# Altium schematic sheet MEDIABOARD-1A.SchDoc
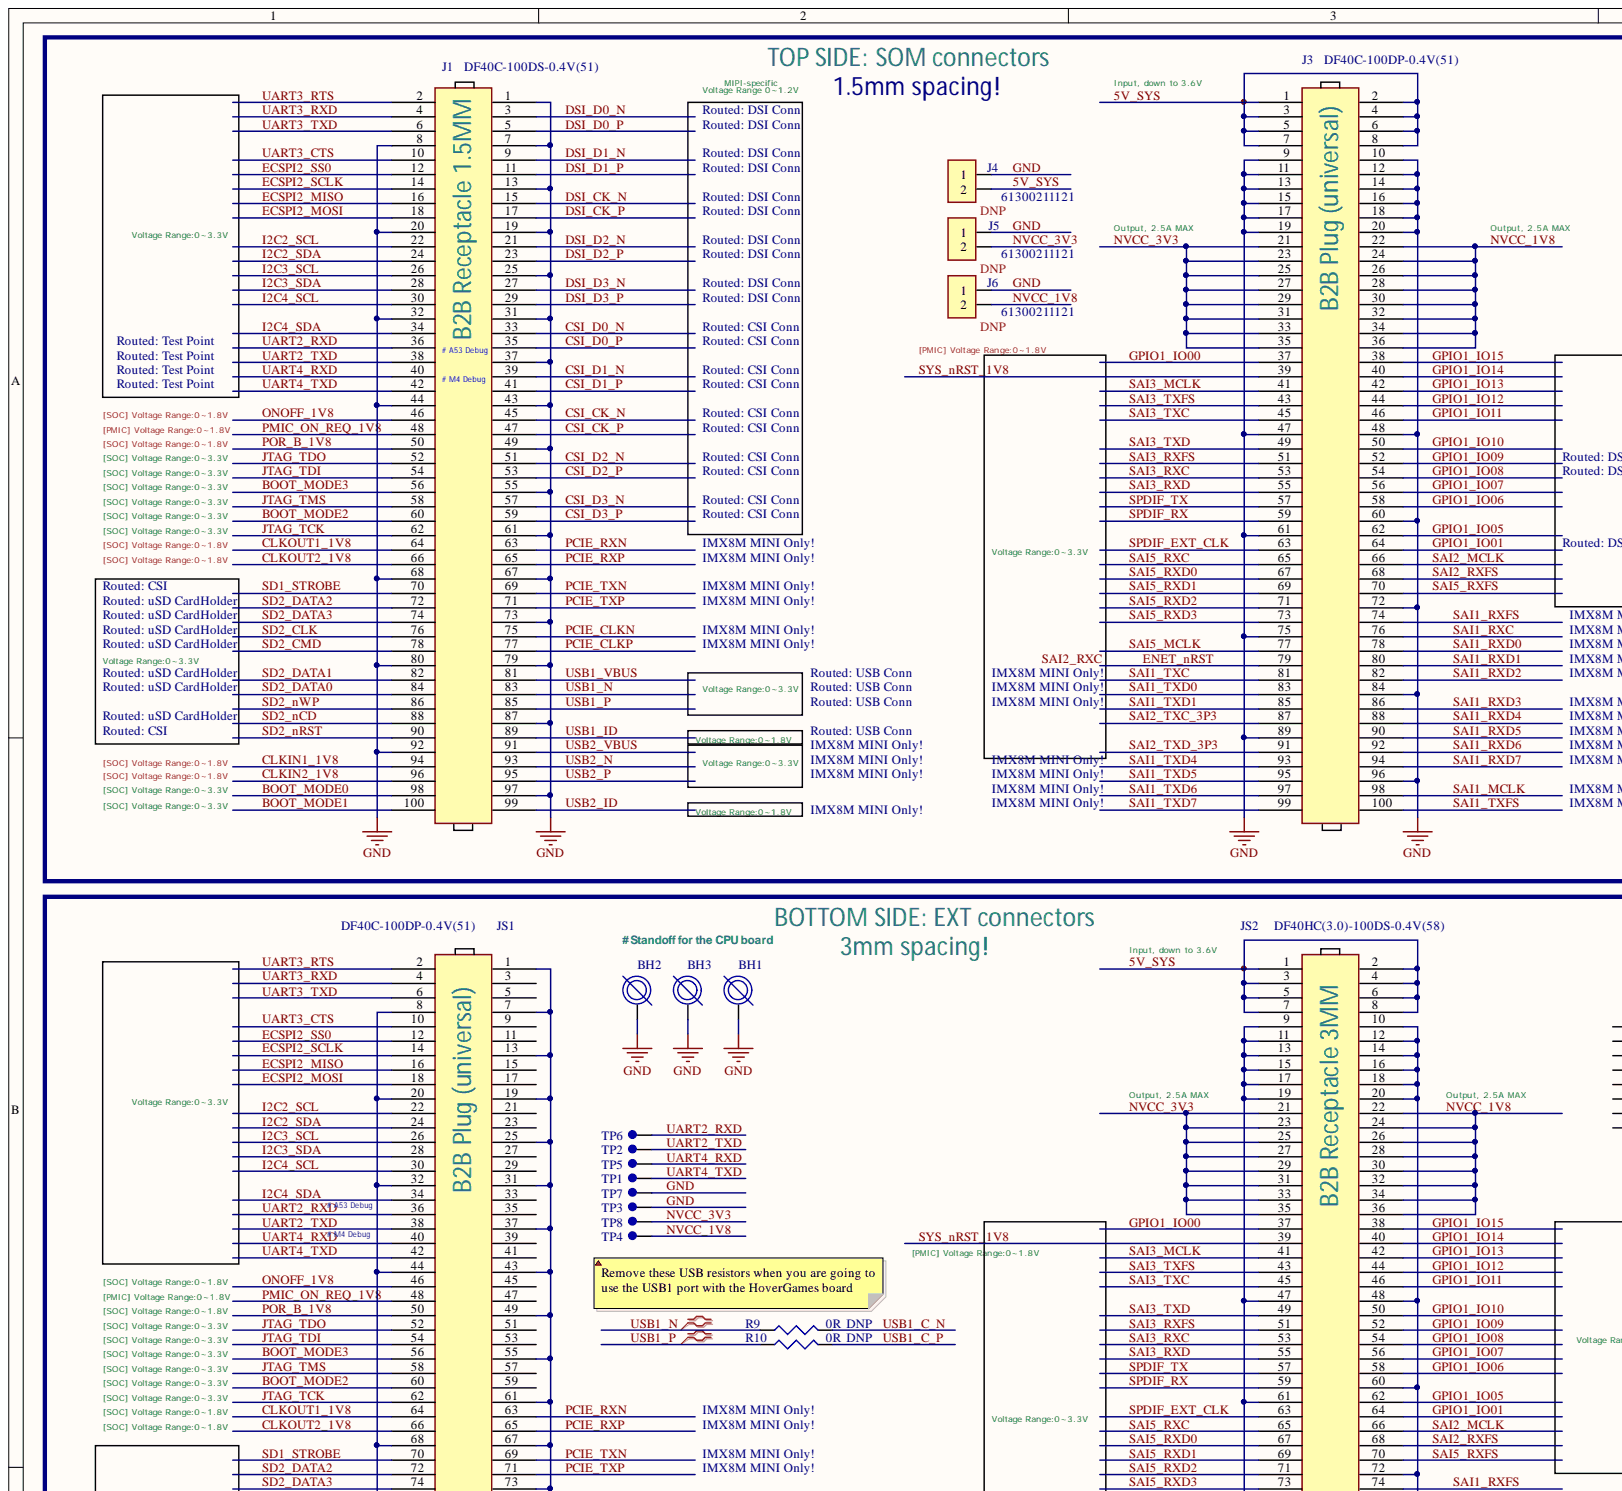
[diagram p0: MEDIABOARD-1A.SchDoc, top left]
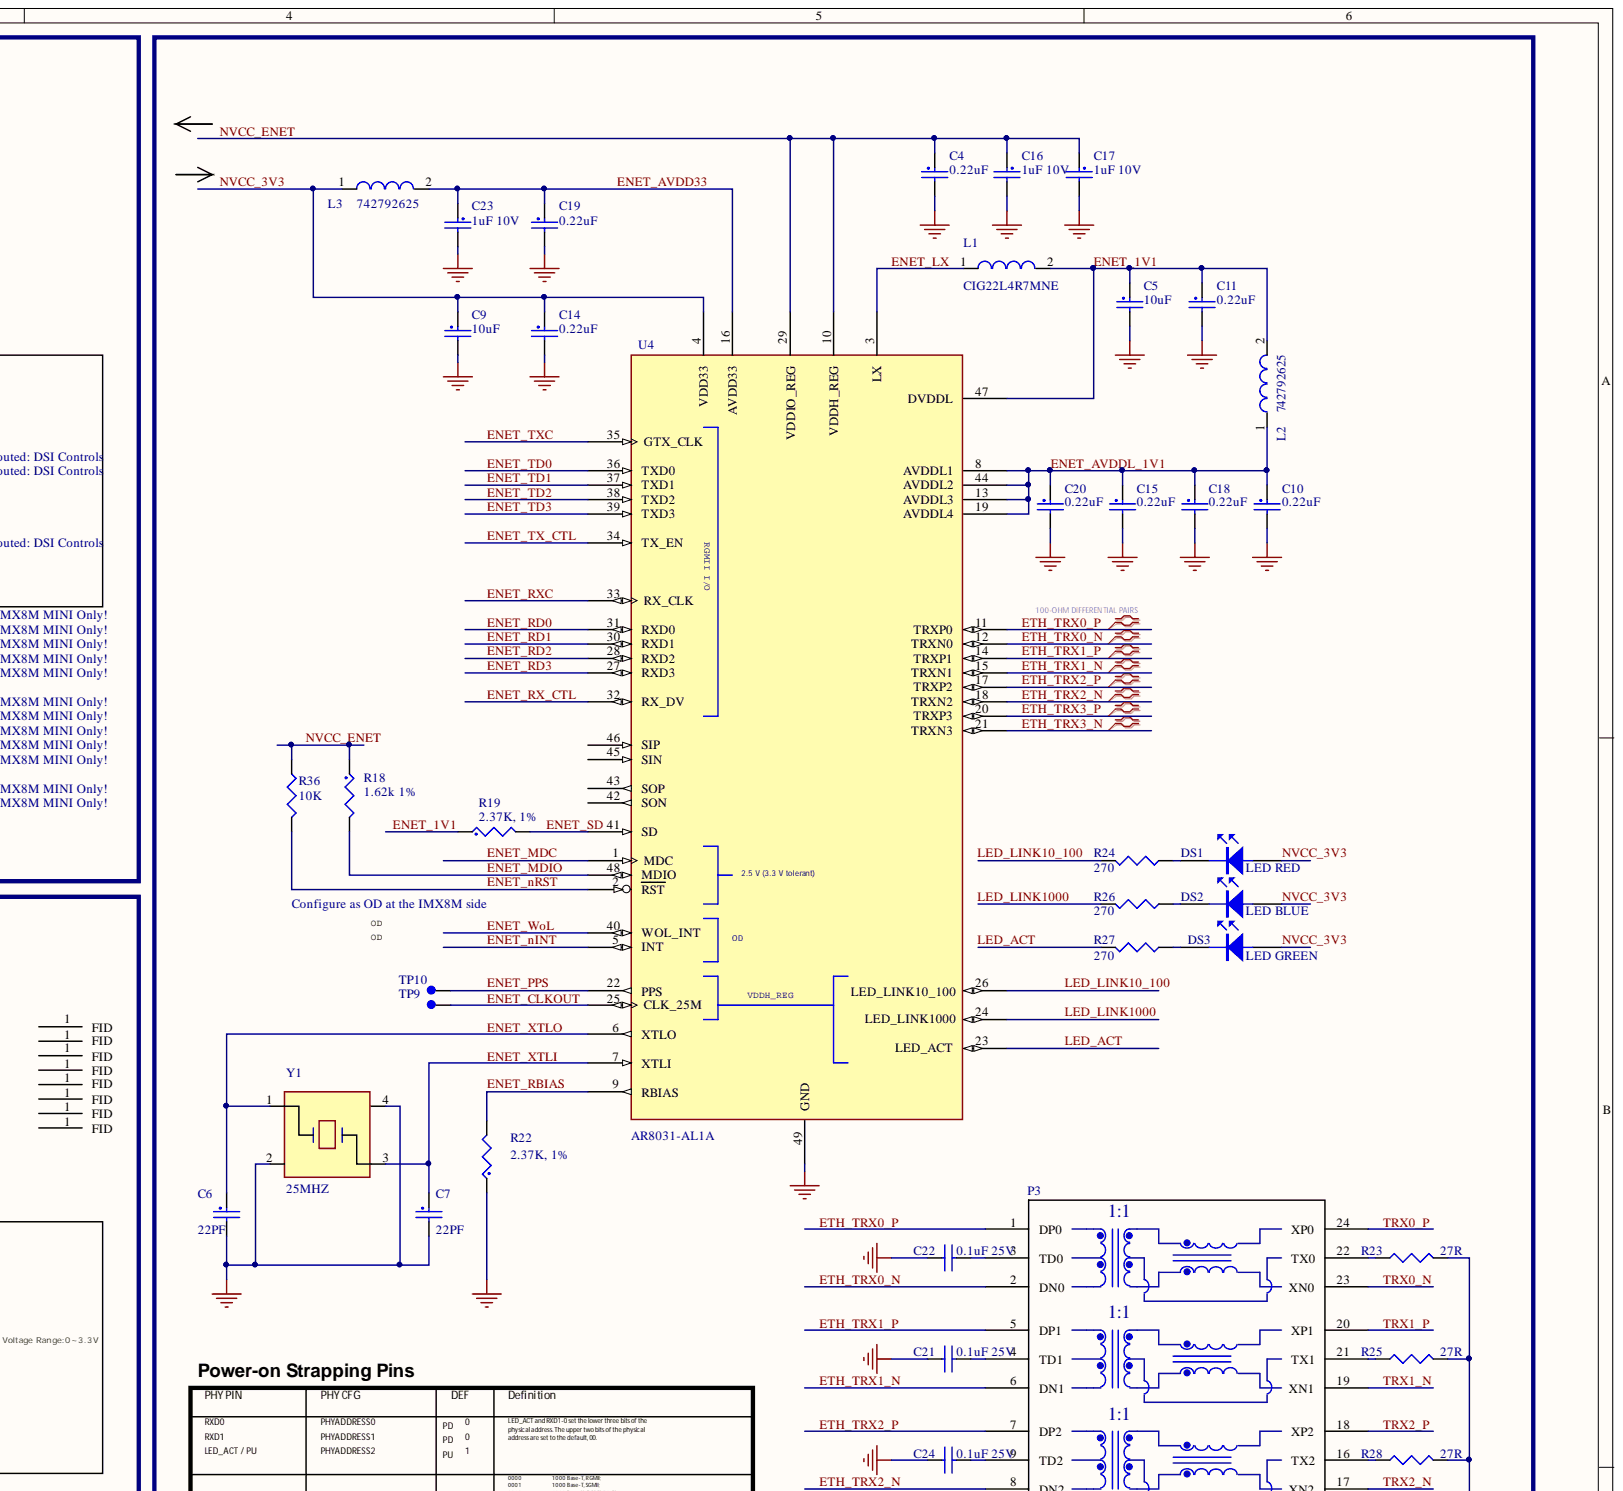
[diagram p1: MEDIABOARD-1A.SchDoc, top right]
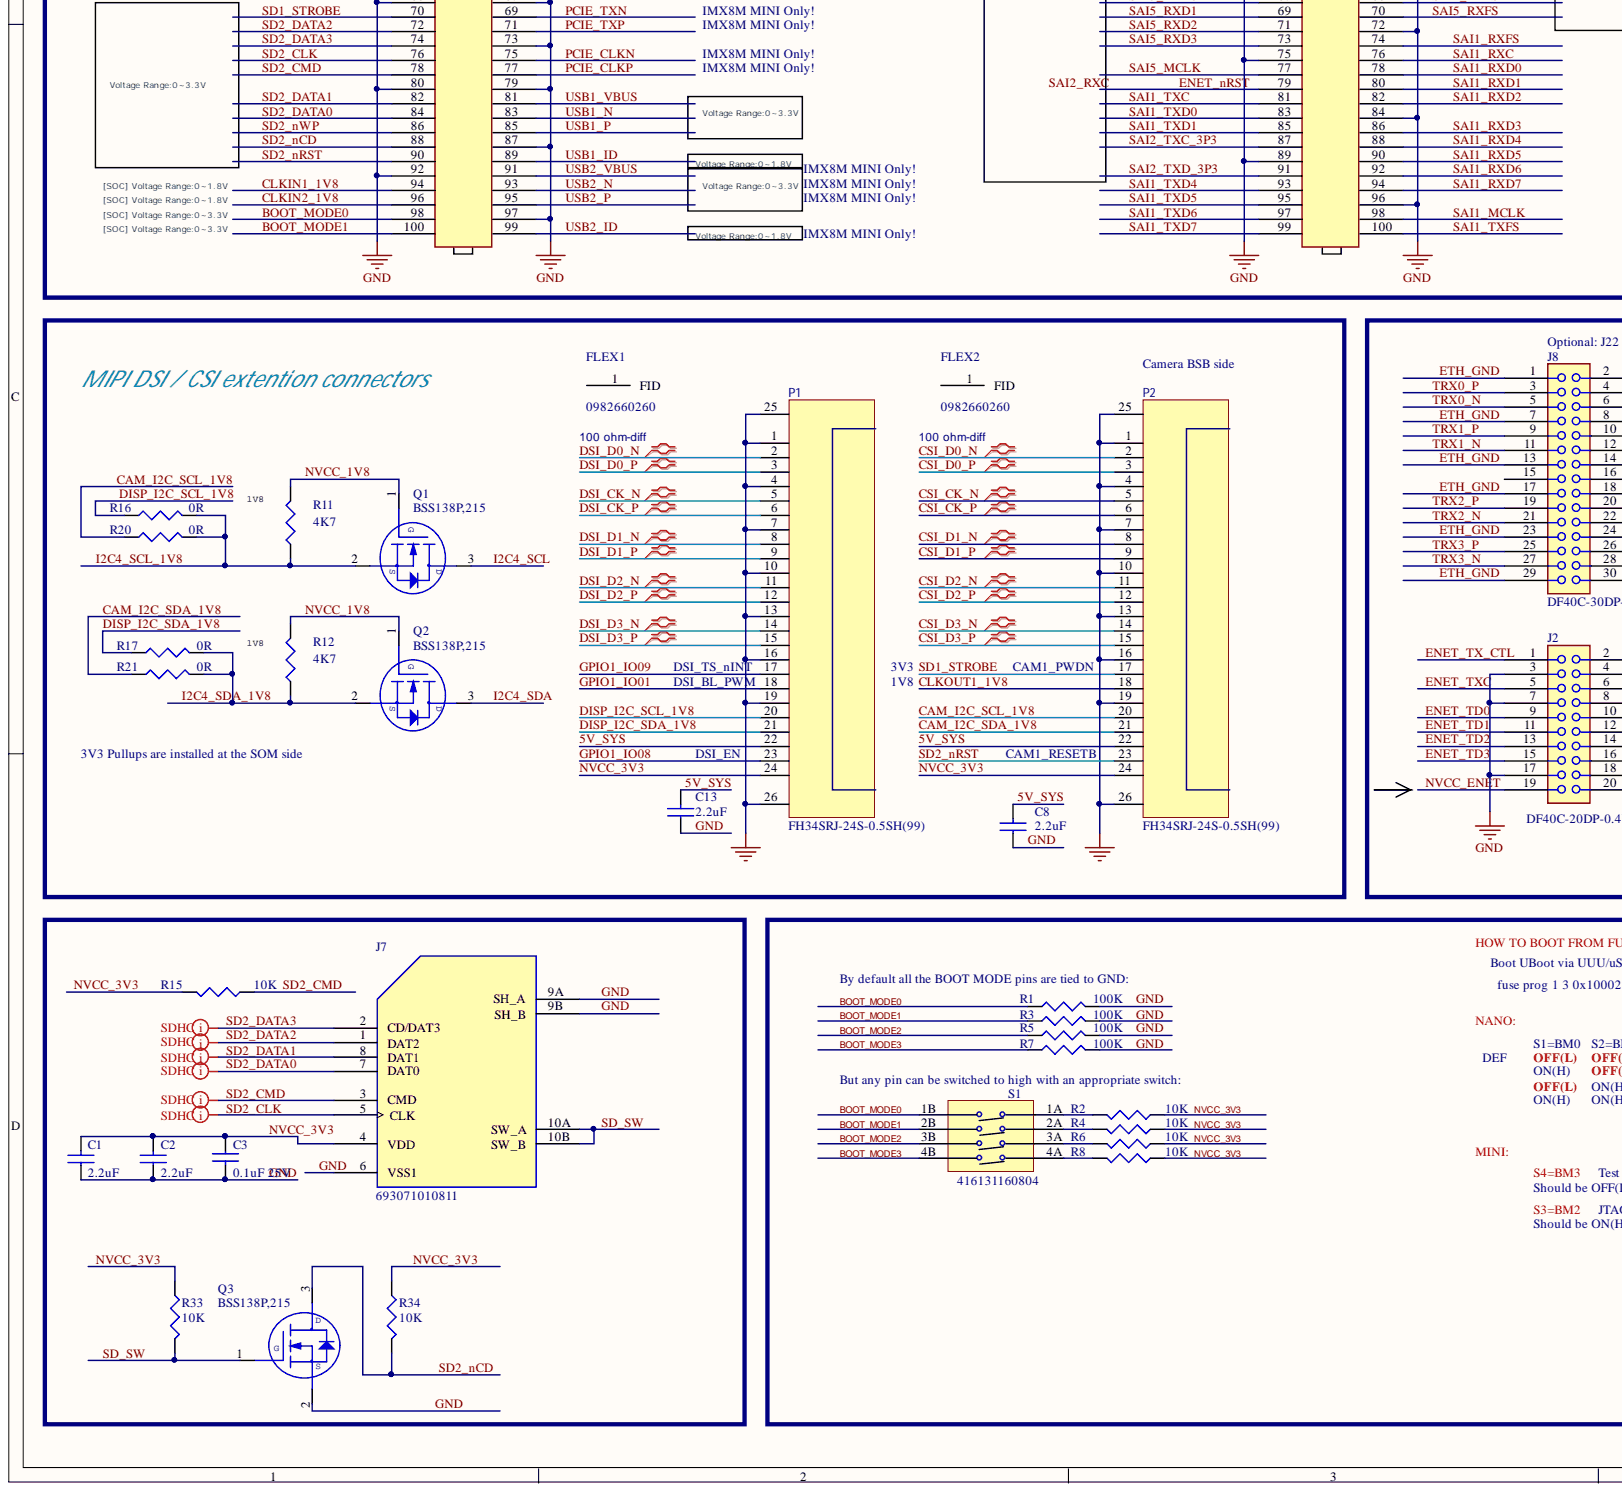
[diagram p2: MEDIABOARD-1A.SchDoc, bottom left]
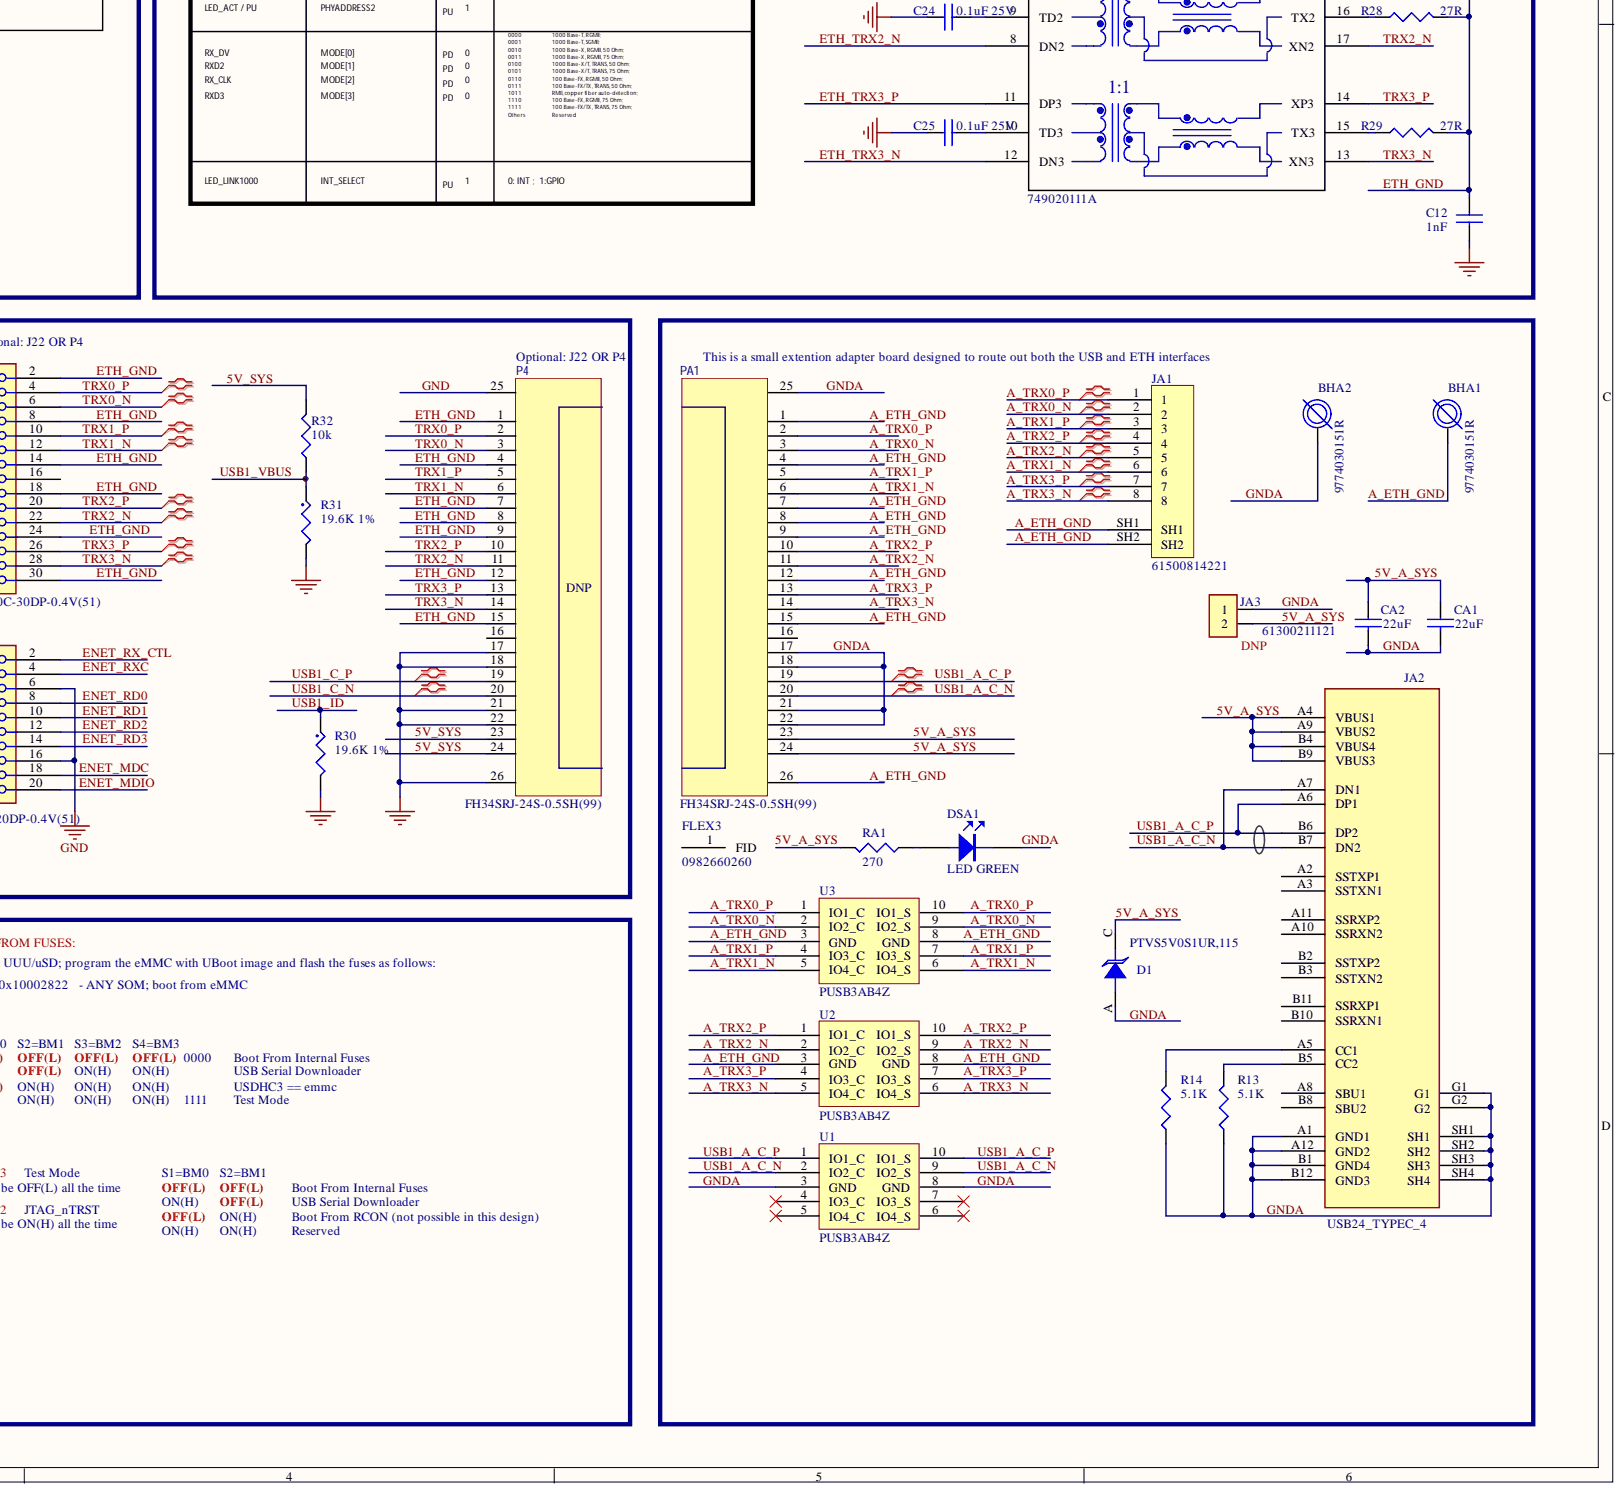
[diagram p3: MEDIABOARD-1A.SchDoc, bottom right]

## Parts
BH1  Spacer M2.5 1.5mm  [standoff_circle_5p1-2p5mm_nsp]  (value not drawn)
BH2  Spacer M2.5 1.5mm  [standoff_circle_5p1-2p5mm_nsp]  (value not drawn)
BH3  Spacer M2.5 1.5mm  [standoff_circle_5p1-2p5mm_nsp]  (value not drawn)
BHA1  9774030151R  [standoff_circle_5p1-2p5mm_nsp_3mm]
BHA2  9774030151R  [standoff_circle_5p1-2p5mm_nsp_3mm]
C1  2.2uF  [CAPC1608X95N]
C2  2.2uF  [CAPC1608X95N]
C3  0.1uF 25V  [CAPC1005X60N]
C4  0.22uF  [CAPC1005X60N]
C5  10uF  [CAPC1608X95N]
C6  22PF  [CAPC1005X60N]
C7  22PF  [CAPC1005X60N]
C8  2.2uF  [CAPC1608X95N]
C9  10uF  [CAPC1608X95N]
C10  0.22uF  [CAPC1005X60N]
C11  0.22uF  [CAPC1005X60N]
C12  1nF  [C1206]
C13  2.2uF  [CAPC1608X95N]
C14  0.22uF  [CAPC1005X60N]
C15  0.22uF  [CAPC1005X60N]
C16  1uF 10V  [CAPC1005X60N]
C17  1uF 10V  [CAPC1005X60N]
C18  0.22uF  [CAPC1005X60N]
C19  0.22uF  [CAPC1005X60N]
C20  0.22uF  [CAPC1005X60N]
C21  0.1uF 25V  [C0402]
C22  0.1uF 25V  [C0402]
C23  1uF 10V  [CAPC1005X60N]
C24  0.1uF 25V  [C0402]
C25  0.1uF 25V  [C0402]
CA1  22uF  [CAPC1608X95N]
CA2  22uF  [CAPC1608X95N]
D1  PTVS5V0S1UR,115  [SOD123W]
DS1  LED RED  [LED2012N]
DS2  LED BLUE  [LED2012N]
DS3  LED GREEN  [LED2012N]
DSA1  LED GREEN  [LED2012N]
FID1  FID  [FID_040]
FID2  FID  [FID_040]
FID3  FID  [FID_040]
FID4  FID  [FID_040]
FID5  FID  [FID_040]
FID6  FID  [FID_040]
FID7  FID  [FID_040]
FID8  FID  [FID_040]
FLEX1  0982660260
FLEX2  0982660260
FLEX3  0982660260
J1  DF40C-100DS-0.4V(51)  [con100_0p4_22p8x3p1_sm]
J2  DF40C-20DP-0.4V(51)  [con20_0p4_5p7x2p05_sm]
J3  DF40C-100DP-0.4V(51)  [con100_0p4_21p7x2p05_sm]
J4  61300211121  Notes: DNP  [CON-SAMTEC-TSW-102-07-T-S]
J5  61300211121  Notes: DNP  [CON-SAMTEC-TSW-102-07-T-S]
J6  61300211121  Notes: DNP  [CON-SAMTEC-TSW-102-07-T-S]
J7  693071010811  [CON_SDCARD_14X15P2_SM_RA]
J8  DF40C-30DP-0.4V(51)  [con30_0p4_5p7x2p05_sm]
JA1  61500814221  [MOLEX_0855055002]
JA2  USB24_TYPEC_4  [632723X00011]
JA3  61300211121  Notes: DNP  [CON-SAMTEC-TSW-102-07-T-S]
JS1  DF40C-100DP-0.4V(51)  [con100_0p4_21p7x2p05_sm]
JS2  DF40HC(3.0)-100DS-0.4V(58)  [con100_0p4_22p8x3p1_sm]
L1  CIG22L4R7MNE  [INDC2520X10N]
L2  742792625  [INDC1608X95N]
L3  742792625  [INDC1608X95N]
P1  FH34SRJ-24S-0.5SH(99)  [FPC24-0_5-14_18x4_71MM]
P2  FH34SRJ-24S-0.5SH(99)  [FPC24-0_5-14_18x4_71MM]
P3  749020111A  [749020111]
P4  FH34SRJ-24S-0.5SH(99)  Notes: DNP  [FPC24-0_5-14_18x4_71MM]
PA1  FH34SRJ-24S-0.5SH(99)  [FPC24-0_5-14_18x4_71MM]
Q1  BSS138P,215  [SOT23]
Q2  BSS138P,215  [SOT23]
Q3  BSS138P,215  [SOT23]
R1  100K  [R0402]
R2  10K  [R0402]
R3  100K  [R0402]
R4  10K  [R0402]
R5  100K  [R0402]
R6  10K  [R0402]
R7  100K  [R0402]
R8  10K  [R0402]
R9  0R  Notes: DNP  [R0402]
R10  0R  Notes: DNP  [R0402]
R11  4K7  [R0402]
R12  4K7  [R0402]
R13  5.1K  [R0402]
R14  5.1K  [R0402]
R15  10K  [R0402]
R16  0R  [R0402]
R17  0R  [R0402]
R18  1.62k 1%  [RESC1005N]
R19  2.37K, 1%  [RESC1005N]
R20  0R  [R0402]
R21  0R  [R0402]
R22  2.37K, 1%  [RESC1005N]
R23  27R  [R0402]
R24  270  [RESC1005N]
R25  27R  [R0402]
R26  270  [RESC1005N]
R27  270  [RESC1005N]
R28  27R  [R0402]
R29  27R  [R0402]
R30  19.6K 1%  [RESC1005N]
R31  19.6K 1%  [RESC1005N]
R32  10k  [RESC1005N]
R33  10K  [R0402]
R34  10K  [R0402]
R36  10K  [R0402]
RA1  270  [RESC1005N]
S1  416131160804  [416131160804]
TP1  Test pad  [TEST1MM]  (value not drawn)
TP2  Test pad  [TEST1MM]  (value not drawn)
TP3  Test pad  [TEST1MM]  (value not drawn)
TP4  Test pad  [TEST1MM]  (value not drawn)
TP5  Test pad  [TEST1MM]  (value not drawn)
TP6  Test pad  [TEST1MM]  (value not drawn)
TP7  Test pad  [TEST1MM]  (value not drawn)
TP8  Test pad  [TEST1MM]  (value not drawn)
TP9  Test pad  [TEST1MM]  (value not drawn)
TP10  Test pad  [TEST1MM]  (value not drawn)
U1  PUSB3AB4Z  [TI_TPD4E05U06DQAR]
U2  PUSB3AB4Z  [TI_TPD4E05U06DQAR]
U3  PUSB3AB4Z  [TI_TPD4E05U06DQAR]
U4  AR8031-AL1A  [QFN48_0P4_6X6MM_EPAD]
Y1  25MHZ  [CTS_403]

## Nets
5V_A_SYS: CA1.1 CA2.1 D1.C JA2.A4(VBUS1) JA2.A9(VBUS2) JA2.B4(VBUS4) JA2.B9(VBUS3) JA3.2 PA1.23 PA1.24 RA1.2
5V_SYS: C8.2 C13.2 J3.1 J3.2 J3.3 J3.4 J3.5 J3.6 J3.7 J3.8 J4.2 JS2.1 JS2.2 JS2.3 JS2.4 JS2.5 JS2.6 JS2.7 JS2.8 P1.22 P2.22 P4.23 P4.24 R32.1
A_ETH_GND: BHA1.1 JA1.SH1 JA1.SH2 PA1.1 PA1.4 PA1.7 PA1.8 PA1.9 PA1.12 PA1.15 PA1.26 U2.3(GND) U2.8(GND) U3.3(GND) U3.8(GND)
A_TRX0_N: JA1.2 PA1.3 U3.2(IO2_C) U3.9(IO2_S)
A_TRX0_P: JA1.1 PA1.2 U3.1(IO1_C) U3.10(IO1_S)
A_TRX1_N: JA1.6 PA1.6 U3.5(IO4_C) U3.6(IO4_S)
A_TRX1_P: JA1.3 PA1.5 U3.4(IO3_C) U3.7(IO3_S)
A_TRX2_N: JA1.5 PA1.11 U2.2(IO2_C) U2.9(IO2_S)
A_TRX2_P: JA1.4 PA1.10 U2.1(IO1_C) U2.10(IO1_S)
A_TRX3_N: JA1.8 PA1.14 U2.5(IO4_C) U2.6(IO4_S)
A_TRX3_P: JA1.7 PA1.13 U2.4(IO3_C) U2.7(IO3_S)
BOOT_MODE0: J1.98 JS1.98 R1.1 S1.1B
BOOT_MODE1: J1.100 JS1.100 R3.1 S1.2B
BOOT_MODE2: J1.60 JS1.60 R5.1 S1.3B
BOOT_MODE3: J1.56 JS1.56 R7.1 S1.4B
CAM_I2C_SCL_1V8: P2.20 R20.1
CAM_I2C_SDA_1V8: P2.21 R21.1
CLKIN1_1V8: J1.94 JS1.94
CLKIN2_1V8: J1.96 JS1.96
CLKOUT1_1V8: J1.64 JS1.64 P2.18
CLKOUT2_1V8: J1.66 JS1.66
CSI_CK_N: J1.45 P2.5
CSI_CK_P: J1.47 P2.6
CSI_D0_N: J1.33 P2.2
CSI_D0_P: J1.35 P2.3
CSI_D1_N: J1.39 P2.8
CSI_D1_P: J1.41 P2.9
CSI_D2_N: J1.51 P2.11
CSI_D2_P: J1.53 P2.12
CSI_D3_N: J1.57 P2.14
CSI_D3_P: J1.59 P2.15
DISP_I2C_SCL_1V8: P1.20 R16.1
DISP_I2C_SDA_1V8: P1.21 R17.1
DSI_CK_N: J1.15 P1.5
DSI_CK_P: J1.17 P1.6
DSI_D0_N: J1.3 P1.2
DSI_D0_P: J1.5 P1.3
DSI_D1_N: J1.9 P1.8
DSI_D1_P: J1.11 P1.9
DSI_D2_N: J1.21 P1.11
DSI_D2_P: J1.23 P1.12
DSI_D3_N: J1.27 P1.14
DSI_D3_P: J1.29 P1.15
ECSPI2_MISO: J1.16 JS1.16
ECSPI2_MOSI: J1.18 JS1.18
ECSPI2_SCLK: J1.14 JS1.14
ECSPI2_SS0: J1.12 JS1.12
ENET_1V1: C5.1 C11.1 L1.2 L2.2 R19.1 U4.47(DVDDL)
ENET_AVDD33: C19.1 C23.1 L3.2 U4.16(AVDD33)
ENET_AVDDL_1V1: C10.1 C15.1 C18.1 C20.1 L2.1 U4.8(AVDDL1) U4.13(AVDDL3) U4.19(AVDDL4) U4.44(AVDDL2)
ENET_CLKOUT: TP9.1 U4.25(CLK_25M)
ENET_LX: L1.1 U4.3(LX)
ENET_MDC: J2.18 U4.1(MDC)
ENET_MDIO: J2.20 R18.2 U4.48(MDIO)
ENET_nINT: U4.5(INT)
ENET_nRST: J3.79 JS2.79 R36.2 U4.2(RST)
ENET_PPS: TP10.1 U4.22(PPS)
ENET_RBIAS: R22.2 U4.9(RBIAS)
ENET_RD0: J2.8 U4.31(RXD0)
ENET_RD1: J2.10 U4.30(RXD1)
ENET_RD2: J2.12 U4.28(RXD2)
ENET_RD3: J2.14 U4.27(RXD3)
ENET_RX_CTL: J2.2 U4.32(RX_DV)
ENET_RXC: J2.4 U4.33(RX_CLK)
ENET_SD: R19.2 U4.41(SD)
ENET_TD0: J2.9 U4.36(TXD0)
ENET_TD1: J2.11 U4.37(TXD1)
ENET_TD2: J2.13 U4.38(TXD2)
ENET_TD3: J2.15 U4.39(TXD3)
ENET_TX_CTL: J2.1 U4.34(TX_EN)
ENET_TXC: J2.5 U4.35(GTX_CLK)
ENET_WoL: U4.40(WOL_INT)
ENET_XTLI: C7.1 U4.7(XTLI) Y1.3
ENET_XTLO: C6.1 U4.6(XTLO) Y1.1
ETH_GND: C12.1 J8.1 J8.2 J8.7 J8.8 J8.13 J8.14 J8.17 J8.18 J8.23 J8.24 J8.29 J8.30 P4.1 P4.4 P4.7 P4.8 P4.9 P4.12 P4.15 R23.1 R25.1 R28.1 R29.1
ETH_TRX0_N: P3.2(DN0) U4.12(TRXN0)
ETH_TRX0_P: P3.1(DP0) U4.11(TRXP0)
ETH_TRX1_N: P3.6(DN1) U4.15(TRXN1)
ETH_TRX1_P: P3.5(DP1) U4.14(TRXP1)
ETH_TRX2_N: P3.8(DN2) U4.18(TRXN2)
ETH_TRX2_P: P3.7(DP2) U4.17(TRXP2)
ETH_TRX3_N: P3.12(DN3) U4.21(TRXN3)
ETH_TRX3_P: P3.11(DP3) U4.20(TRXP3)
GND: BH1.1 BH2.1 BH3.1 C1.1 C2.1 C3.2 C4.2 C5.2 C6.2 C7.2 C8.1 C9.2 C10.2 C11.2 C12.2 C13.1 C14.2 C15.2 C16.2 C17.2 C18.2 C19.2 C20.2 C21.1 C22.1 C23.2 C24.1 C25.1 J1.1 J1.7 J1.8 J1.13 J1.19 J1.20 J1.25 J1.31 J1.32 J1.37 J1.43 J1.44 J1.49 J1.55 J1.61 J1.67 J1.68 J1.73 J1.79 J1.80 J1.87 J1.92 J1.97 J2.3 J2.6 J2.7 J2.16 J2.17 J3.9 J3.10 J3.11 J3.12 J3.13 J3.14 J3.15 J3.16 J3.17 J3.18 J3.19 J3.20 J3.47 J3.48 J3.60 J3.61 J3.72 J3.75 J3.84 J3.89 J3.96 J4.1 J5.1 J6.1 J7.6(VSS1) J7.9A(SH_A) J7.9B(SH_B) JS1.1 JS1.7 JS1.8 JS1.13 JS1.19 JS1.20 JS1.25 JS1.31 JS1.32 JS1.37 JS1.43 JS1.44 JS1.49 JS1.55 JS1.61 JS1.67 JS1.68 JS1.73 JS1.79 JS1.80 JS1.87 JS1.92 JS1.97 JS2.9 JS2.10 JS2.11 JS2.12 JS2.13 JS2.14 JS2.15 JS2.16 JS2.17 JS2.18 JS2.19 JS2.20 JS2.47 JS2.48 JS2.60 JS2.61 JS2.72 JS2.75 JS2.84 JS2.89 JS2.96 P1.1 P1.4 P1.7 P1.10 P1.13 P1.16 P1.19 P1.25 P1.26 P2.1 P2.4 P2.7 P2.10 P2.13 P2.16 P2.19 P2.25 P2.26 P4.17 P4.18 P4.21 P4.22 P4.25 P4.26 Q3.2 R1.2 R3.2 R5.2 R7.2 R22.1 R30.2 R31.2 TP3.1 TP7.1 U4.49(GND) Y1.2 Y1.4
GNDA: BHA2.1 CA1.2 CA2.2 D1.A DSA1.2 JA2.A1(GND1) JA2.A12(GND2) JA2.B1(GND4) JA2.B12(GND3) JA2.G1 JA2.G2 JA2.SH1 JA2.SH2 JA2.SH3 JA2.SH4 JA3.1 PA1.17 PA1.18 PA1.21 PA1.22 PA1.25 R13.1 R14.1 U1.3(GND) U1.8(GND)
GPIO1_IO00: J3.37 JS2.37
GPIO1_IO01: J3.64 JS2.64 P1.18
GPIO1_IO05: J3.62 JS2.62
GPIO1_IO06: J3.58 JS2.58
GPIO1_IO07: J3.56 JS2.56
GPIO1_IO08: J3.54 JS2.54 P1.23
GPIO1_IO09: J3.52 JS2.52 P1.17
GPIO1_IO10: J3.50 JS2.50
GPIO1_IO11: J3.46 JS2.46
GPIO1_IO12: J3.44 JS2.44
GPIO1_IO13: J3.42 JS2.42
GPIO1_IO14: J3.40 JS2.40
GPIO1_IO15: J3.38 JS2.38
I2C2_SCL: J1.22 JS1.22
I2C2_SDA: J1.24 JS1.24
I2C3_SCL: J1.26 JS1.26
I2C3_SDA: J1.28 JS1.28
I2C4_SCL: J1.30 JS1.30 Q1.3
I2C4_SCL_1V8: Q1.2 R11.1 R16.2 R20.2
I2C4_SDA: J1.34 JS1.34 Q2.3
I2C4_SDA_1V8: Q2.2 R12.1 R17.2 R21.2
JTAG_TCK: J1.62 JS1.62
JTAG_TDI: J1.54 JS1.54
JTAG_TDO: J1.52 JS1.52
JTAG_TMS: J1.58 JS1.58
LED_ACT: R27.2 U4.23(LED_ACT)
LED_LINK10_100: R24.2 U4.26(LED_LINK10_100)
LED_LINK1000: R26.2 U4.24(LED_LINK1000)
NVCC_1V8: J3.22 J3.24 J3.26 J3.28 J3.30 J3.32 J3.34 J3.36 J6.2 JS2.22 JS2.24 JS2.26 JS2.28 JS2.30 JS2.32 JS2.34 JS2.36 Q1.1 Q2.1 R11.2 R12.2 TP4.1
NVCC_3V3: C1.2 C2.2 C3.1 C9.1 C14.1 DS1.1 DS2.1 DS3.1 J3.21 J3.23 J3.25 J3.27 J3.29 J3.31 J3.33 J3.35 J5.2 J7.4(VDD) JS2.21 JS2.23 JS2.25 JS2.27 JS2.29 JS2.31 JS2.33 JS2.35 L3.1 P1.24 P2.24 R2.1 R4.1 R6.1 R8.1 R15.2 R33.1 R34.1 TP8.1 U4.4(VDD33)
NVCC_ENET: C4.1 C16.1 C17.1 J2.19 R18.1 R36.1 U4.10(VDDH_REG) U4.29(VDDIO_REG)
ONOFF_1V8: J1.46 JS1.46
PCIE_CLKN: J1.75 JS1.75
PCIE_CLKP: J1.77 JS1.77
PCIE_RXN: J1.63 JS1.63
PCIE_RXP: J1.65 JS1.65
PCIE_TXN: J1.69 JS1.69
PCIE_TXP: J1.71 JS1.71
PMIC_ON_REQ_1V8: J1.48 JS1.48
POR_B_1V8: J1.50 JS1.50
SAI1_MCLK: J3.98 JS2.98
SAI1_RXC: J3.76 JS2.76
SAI1_RXD0: J3.78 JS2.78
SAI1_RXD1: J3.80 JS2.80
SAI1_RXD2: J3.82 JS2.82
SAI1_RXD3: J3.86 JS2.86
SAI1_RXD4: J3.88 JS2.88
SAI1_RXD5: J3.90 JS2.90
SAI1_RXD6: J3.92 JS2.92
SAI1_RXD7: J3.94 JS2.94
SAI1_RXFS: J3.74 JS2.74
SAI1_TXC: J3.81 JS2.81
SAI1_TXD0: J3.83 JS2.83
SAI1_TXD1: J3.85 JS2.85
SAI1_TXD4: J3.93 JS2.93
SAI1_TXD5: J3.95 JS2.95
SAI1_TXD6: J3.97 JS2.97
SAI1_TXD7: J3.99 JS2.99
SAI1_TXFS: J3.100 JS2.100
SAI2_MCLK: J3.66 JS2.66
SAI2_RXFS: J3.68 JS2.68
SAI2_TXC_3P3: J3.87 JS2.87
SAI2_TXD_3P3: J3.91 JS2.91
SAI3_MCLK: J3.41 JS2.41
SAI3_RXC: J3.53 JS2.53
SAI3_RXD: J3.55 JS2.55
SAI3_RXFS: J3.51 JS2.51
SAI3_TXC: J3.45 JS2.45
SAI3_TXD: J3.49 JS2.49
SAI3_TXFS: J3.43 JS2.43
SAI5_MCLK: J3.77 JS2.77
SAI5_RXC: J3.65 JS2.65
SAI5_RXD0: J3.67 JS2.67
SAI5_RXD1: J3.69 JS2.69
SAI5_RXD2: J3.71 JS2.71
SAI5_RXD3: J3.73 JS2.73
SAI5_RXFS: J3.70 JS2.70
SD1_STROBE: J1.70 JS1.70 P2.17
SD2_CLK: J1.76 J7.5(CLK) JS1.76
SD2_CMD: J1.78 J7.3(CMD) JS1.78 R15.1
SD2_DATA0: J1.84 J7.7(DAT0) JS1.84
SD2_DATA1: J1.82 J7.8(DAT1) JS1.82
SD2_DATA2: J1.72 J7.1(DAT2) JS1.72
SD2_DATA3: J1.74 J7.2(CD/DAT3) JS1.74
SD2_nCD: J1.88 JS1.88 Q3.3 R34.2
SD2_nRST: J1.90 JS1.90 P2.23
SD2_nWP: J1.86 JS1.86
SD_SW: J7.10A(SW_A) J7.10B(SW_B) Q3.1 R33.2
SPDIF_EXT_CLK: J3.63 JS2.63
SPDIF_RX: J3.59 JS2.59
SPDIF_TX: J3.57 JS2.57
SYS_nRST_1V8: J3.39 JS2.39
TRX0_N: J8.5 J8.6 P3.23(XN0) P4.3
TRX0_P: J8.3 J8.4 P3.24(XP0) P4.2
TRX1_N: J8.11 J8.12 P3.19(XN1) P4.6
TRX1_P: J8.9 J8.10 P3.20(XP1) P4.5
TRX2_N: J8.21 J8.22 P3.17(XN2) P4.11
TRX2_P: J8.19 J8.20 P3.18(XP2) P4.10
TRX3_N: J8.27 J8.28 P3.13(XN3) P4.14
TRX3_P: J8.25 J8.26 P3.14(XP3) P4.13
UART2_RXD: J1.36 JS1.36 TP6.1
UART2_TXD: J1.38 JS1.38 TP2.1
UART3_CTS: J1.10 JS1.10
UART3_RTS: J1.2 JS1.2
UART3_RXD: J1.4 JS1.4
UART3_TXD: J1.6 JS1.6
UART4_RXD: J1.40 JS1.40 TP5.1
UART4_TXD: J1.42 JS1.42 TP1.1
USB1_A_C_N: JA2.A7(DN1) JA2.B7(DN2) PA1.20 U1.2(IO2_C) U1.9(IO2_S)
USB1_A_C_P: JA2.A6(DP1) JA2.B6(DP2) PA1.19 U1.1(IO1_C) U1.10(IO1_S)
USB1_C_N: P4.20 R9.2
USB1_C_P: P4.19 R10.2
USB1_ID: J1.89 JS1.89 R30.1
USB1_N: J1.83 JS1.83 R9.1
USB1_P: J1.85 JS1.85 R10.1
USB1_VBUS: J1.81 JS1.81 R31.1 R32.2
USB2_ID: J1.99 JS1.99
USB2_N: J1.93 JS1.93
USB2_P: J1.95 JS1.95
USB2_VBUS: J1.91 JS1.91
NetC21_2: C21.2 P3.4(TD1)
NetC22_2: C22.2 P3.3(TD0)
NetC24_2: C24.2 P3.9(TD2)
NetC25_2: C25.2 P3.10(TD3)
NetDS1_2: DS1.2 R24.1
NetDS2_2: DS2.2 R26.1
NetDS3_2: DS3.2 R27.1
NetDSA1_1: DSA1.1 RA1.1
NetJA2_A5: JA2.A5(CC1) R14.2
NetJA2_B5: JA2.B5(CC2) R13.2
NetP3_15: P3.15(TX3) R29.2
NetP3_16: P3.16(TX2) R28.2
NetP3_21: P3.21(TX1) R25.2
NetP3_22: P3.22(TX0) R23.2
NetR2_2: R2.2 S1.1A
NetR4_2: R4.2 S1.2A
NetR6_2: R6.2 S1.3A
NetR8_2: R8.2 S1.4A
SAI2_RXC:

Not connected: FID1 1; FID2 1; FID3 1; FID4 1; FID5 1; FID6 1; FID7 1; FID8 1; FLEX1 1; FLEX2 1; FLEX3 1; J8 15 16; JA2 A2(SSTXP1) A3(SSTXN1) A8(SBU1) A10(SSRXN2) A11(SSRXP2) B2(SSTXP2) B3(SSTXN2) B8(SBU2) B10(SSRXN1) B11(SSRXP1); JS1 3 5 9 11 15 17 21 23 27 29 33 35 39 41 45 47 51 53 57 59; P4 16; PA1 16; U1 4(IO3_C) 5(IO4_C) 6(IO4_S) 7(IO3_S); U4 42(SON) 43(SOP) 45(SIN) 46(SIP)

## Library data of the parts
BH1-BH3 = MOUNT_HOLE: ROUND SPACER STEEL 1.5MM; Manufacturer: Würth Elektronik; ManType: 9774015951R; Component Kind: Standard; DigiKey: 732-7070-1-ND
BHA1 BHA2 = MOUNT_HOLE: RND STANDOFF M2.5X0.45 STEEL 3MM; Manufacturer: Würth Elektronik; Component Kind: Standard; DigiKey: 732-7083-6-ND; ManType: 9774030151R
C1 C2 C8 C13 = CAP NP: CAP CER 2.2UF 10V X5R 0402; Manufacturer: Würth Elektronik; ManType: 885012105013; Component Kind: Standard; DigiKey: 732-7490-6-ND
C3 = CAP NP: CAP CER 0.1UF 25V X5R 0402; Manufacturer: Würth Elektronik; ManType: 885012105018; Notes: Option: C1005X5R0J104K; Component Kind: Standard; DigiKey: 732-7495-1-ND
C4 C10 C11 C14 C15 C18-C20 = CAP_NP_Dup1: CAP CER 0.22UF 16V X5R 0402; Manufacturer: Würth Elektronik; ManType: 885012105017; Component Kind: Standard; DigiKey: 732-7494-2-ND
C5 C9 = CAP_NP_Dup1: CAP CER 10UF 6.3V X5R 0603; Manufacturer: Würth Elektronik; ManType: 885012106006; Component Kind: Standard; DigiKey: 732-7903-1-ND
C6 C7 = CAP_NP_Dup1: CAP CER 22PF 50V 5% NPO 0402; Manufacturer: YAGEO; Part #: E-CAP-012; ManType: CC0402JRNPO9BN220; Component Kind: Standard; Digikey: 311-1018-2-ND; Package: 0402
C12 = CAP_CERAMIC: 1000pF 1kV 10%  X7R; Manufacturer: Murata Electronics; ManType: GRM31BR73A102KW01L; Component Kind: Standard; DigiKey: 490-3494-1-ND
C16 C17 C23 = CAP_NP_Dup1: CAP CER 1UF 10V X5R 0402; Manufacturer: Würth Elektronik; ManType: 885012105012; Component Kind: Standard; DigiKey: 732-7489-6-ND
C21 C22 C24 C25 = CAP NP: CAP CER 0.1UF 25V X5R 0402; Manufacturer: Würth Elektronik; ManType: 885012105018; Component Kind: Standard; DigiKey: 732-7495-1-ND
CA1 CA2 = CAP NP: 22uF +-20% 6.3V Ceramic Capacitor X5R 0603; Manufacturer: Samsung; Emcraft #: E-CAP-049; ManType: CL10A226MQ8NRNC; Component Kind: Standard; Digikey: 1276-1193-1-ND; Package: 0603; Part #: E-CAP-049; Price 100: 0.0850; Price 1000: 0.05250
D1 = DIODE_TVS: TVS DIODE 5V 9.2V CFP3; Manufacturer: Nexperia USA Inc.; Component Kind: Standard; Digikey: 1727-5229-1-ND; ManType: PTVS5V0S1UR,115
DS1 = LED_1: LED RED CLEAR 0805 SMD; Manufacturer: Würth Elektronik; ManType: 150080RS75000; Component Kind: Standard; DigiKey: 732-4984-6-ND
DS2 = LED_1: LED BLUE CLEAR 0805 SMD; Manufacturer: Würth Elektronik; ManType: 150080BS75000; Component Kind: Standard; DigiKey: 732-4982-1-ND
DS3 DSA1 = LED_1: LED GREEN CLEAR 0805 SMD; Manufacturer: Würth Elektronik; ManType: 150080GS75000; Component Kind: Standard; DigiKey: 732-4983-1-ND
FID1-FID8 = FID: Notes: DNP; Component Kind: Standard
FLEX1-FLEX3 = FID: CABLE FFC 24POS 0.50MM 6"; Manufacturer: Molex; Component Kind: Standard; DigiKey: WM14113-ND; ManType: 0982660260
J1 JS2 = HDR_2X50_M: CONN RCPT 100POS SMD GOLD; Manufacturer: Hirose Electric Co Ltd; Component Kind: Standard
  J1: DigiKey: H11615CT-ND; ManType: DF40C-100DS-0.4V(51)
  JS2: DigiKey: H124602CT-ND; ManType: DF40HC(3.0)-100DS-0.4V(58)
J2 = CONN_HDR_10X2: CONN PLUG 20POS SMD GOLD; Manufacturer: Hirose Electric Co Ltd; Component Kind: Standard; DigiKey: H11618CT-ND; ManType: DF40C-20DP-0.4V(51)
J3 JS1 = HDR_2X50_M: CONN PLUG 100POS SMD GOLD; Manufacturer: Hirose Electric Co Ltd; Component Kind: Standard; DigiKey: H11614CT-ND; ManType: DF40C-100DP-0.4V(51)
J4-J6 JA3 = JUMPER_1x2: CONN HEADER VERT 2POS 2.54MM; Manufacturer: Würth Elektronik; Component Kind: Standard; DigiKey: 732-5315-ND; ManType: 61300211121
J7 = MicroSD_WE: CONN MICRO SD CARD PUSH-PUSH R/A; Manufacturer: Würth Elektronik; Component Kind: Standard; Digikey: 732-3819-1-ND; Library Name: main.SchLib; ManType: 693071010811
J8 = CONN_HDR_15X2: CONN PLUG 30POS SMD GOLD; Manufacturer: Hirose Electric Co Ltd; Component Kind: Standard; DigiKey: H11622CT-ND; Library Name: main.SchLib; ManType: DF40C-30DP-0.4V(51)
JA1 = CONN8_PADS: CONN MOD JACK 8P8C R/A SHIELDED; Manufacturer: Würth Elektronik; Component Kind: Standard; DigiKey: 732-2111-ND; Library Name: main.SchLib; ManType: 61500814221
JA2 = USB24_TYPEC_4: CONN RCP USB3.1 TYPEC 24P SMD RA; Manufacturer: Würth Elektronik; ManType: 632723300011; Component Kind: Standard; Digikey: 732-9618-6-ND; Library Name: main.SchLib
L1 = INDUCTOR_Dup1: 4.7uH Shielded Multilayer Inductor 1.1A 110 mOhm 1008; Manufacturer: SAMSUNG; Component Kind: Standard; Digikey: 1276-6213-1-ND; ManType: CIG22L4R7MNE
L2 L3 = INDUCTOR_Dup1: FERRITE BEAD 120 OHM 0603 1LN; Manufacturer: Würth Elektronik; Component Kind: Standard; Digikey: 732-4665-1-ND; ManType: 742792625
P1 P2 P4 PA1 = CONN_ACES_50696-0240MV02: CONN FPC/FFC 24POS .5MM; Manufacturer: Hirose Electric Co Ltd; Component Kind: Standard; DigiKey: H124725CT-ND; ManType: FH34SRJ-24S-0.5SH(99); Library Name: SpacePark.SchLib
P3 = 749020111: WE-LAN 10/100/1000 BASE-T SMT TR; Manufacturer: Würth Elektronik; Component Kind: Standard; Digikey: 732-14052-1-ND; Library Name: main.SchLib; ManType: 749020111A
Q1-Q3 = MOSFET-N-GSD: MOSFET N-CH 60V 360MA TO-236AB; Manufacturer: Nexperia USA Inc.; Component Kind: Standard; DigiKey: 1727-1142-1-ND; ManType: BSS138P,215
R1 R3 R5 R7 = R_SM: RES, 100K, 1%, 1/10W, 0402; Manufacturer: Panasonic Electronic Components; ManType: ERJ-2RKF1003X; Component Kind: Standard; DigiKey: P100KLCT-ND
R2 R4 R6 R8 R15 R33 R34 R36 = R_SM: RES 10K OHM 1/16W 5% 0402; Manufacturer: Stackpole Electronics Inc; ManType: RMCF0402JT10K0; Component Kind: Standard; DigiKey: RMCF0402JT10K0CT-ND
R9 R10 R16 R17 R20 R21 = R_0201_0: RES 0.0 OHM 1/16W JUMP 0402; Manufacturer: STACKPOLE; Part #: E-RES-009; ManType: RMCF0402ZT0R00; Component Kind: Standard; Signal Integrity: RES; Simulation: RES; Library Name: links.SchLib
R11 R12 = R_SM: RES, 4K7, 5%, 1/10W, 0402; Manufacturer: Panasonic; Part #: E-RES-005; ManType: ERJ-2GEJ472X; Component Kind: Standard; PartNumber: ERJ-2GEJ472X
R13 R14 = R_SM: RES 5.1K OHM 1% 1/16W 0402; Manufacturer: Stackpole Electronics Inc; ManType: RMCF0402FT5K10; Component Kind: Standard; DigiKey: RMCF0402FT5K10CT-ND
R18 = RES_Dup1: RES SMD 1.62K OHM 1% 1/16W 0402; Manufacturer: Yageo; Part #: LF-RES-418; ManType: RC0402FR-071K62L; Component Kind: Standard; Digikey: YAG3042TR-ND; Package: 0402
R19 R22 = RES_Dup1: Res, 2.37K ohm, 1%, 0402; Manufacturer: Panasonic; Part #: I-RES-004; ManType: ERJ-2RKF2371X; Component Kind: Standard; Digikey: P2.37KLCT-ND; Package: 0402
R23 R25 R28 R29 = R_SM: RES, 27R, 1%, 1/10W, 0402; Manufacturer: Panasonic; Part #: E-RES-082; Part_Type: Resistor; PartNumber: ERJ-2RKF27R0X-1; Arena PN: 220-0328; Cat_Code: 220_RESISTOR; Circuit: LDS; Height_mm: 0.35; Library Rev: 1; ManType: ERJ-2RKF27R0X; REUSE_ANNOTATE: TRUE; Component Kind: Standard; Manufacturer's Part Number: ERJ-2RKF27R0X; Power: 1/10W
R24 R26 R27 RA1 = R_SH: RESISTOR TF 270 OHM 1% 0.0625W 0402; Manufacturer: Stackpole Electronics Inc; Part #: E-RES-008; ManType: RMCF0402FT270R; Component Kind: Standard; Digikey: RMCF0402FT270RCT-ND; Package: 0402; Price 100: 0.01050
R30 R31 = RES_Dup1: RES SMD 19.6K OHM 1% 1/16W 0402; Manufacturer: Yageo; Part #: E-RES-078; ARENA PN: 220-0478; ManType: RC0402FR-0719K6L; Component Kind: Standard; Digikey: YAG3026CT-ND; Package: 0402; Part#: E-RES-078; Price 100: 0.0055
R32 = R_SM: RES 10K OHM 1/16W 5% 0402; Manufacturer: Stackpole Electronics Inc; Part #: E-RES-003; ManType: RMCF0402JT10K0; Component Kind: Standard; Digikey: RMCF0402JT10K0CT-ND; Package: 0402; Price 100: 0.00700
S1 = 416131160804: SWITCH SLIDE DIP SPST 25MA 24V; Manufacturer: Wurth Electronics; Component Kind: Standard; DigiKey: 732-3854-1-ND; Library Name: main.SchLib; ManType: 416131160804
TP1-TP10 = Testpad: Round Test Point 1mm; CLASS: IC; Notes: DNP; Component Kind: Standard
U1-U3 = ESD224DQAR: TVS DIODE 3.3V 5V DFN2510A-10; Manufacturer: Nexperia USA Inc.; Component Kind: Standard; DigiKey: 1727-2741-1-ND; Library Name: main.SchLib; ManType: PUSB3AB4Z
U4 = AR8031_QFN48: IC TRANSCEIVER ETHERNET 48-QFN; Manufacturer: Qualcomm; Part #: E-IC-065; Component Kind: Standard; Digikey: AR8031-AL1A-ND; ManType: AR8031-AL1A; Package: 48-QFN; Price 100: 2.394
Y1 = XTAL_13Y: CRYSTAL 25.0000MHZ 18PF SMD; Manufacturer: CTS; ManType: 403C35D25M00000; Component Kind: Standard; Digikey: CTX953CT-ND
